# Altium schematic sheet Mcu.SchDoc
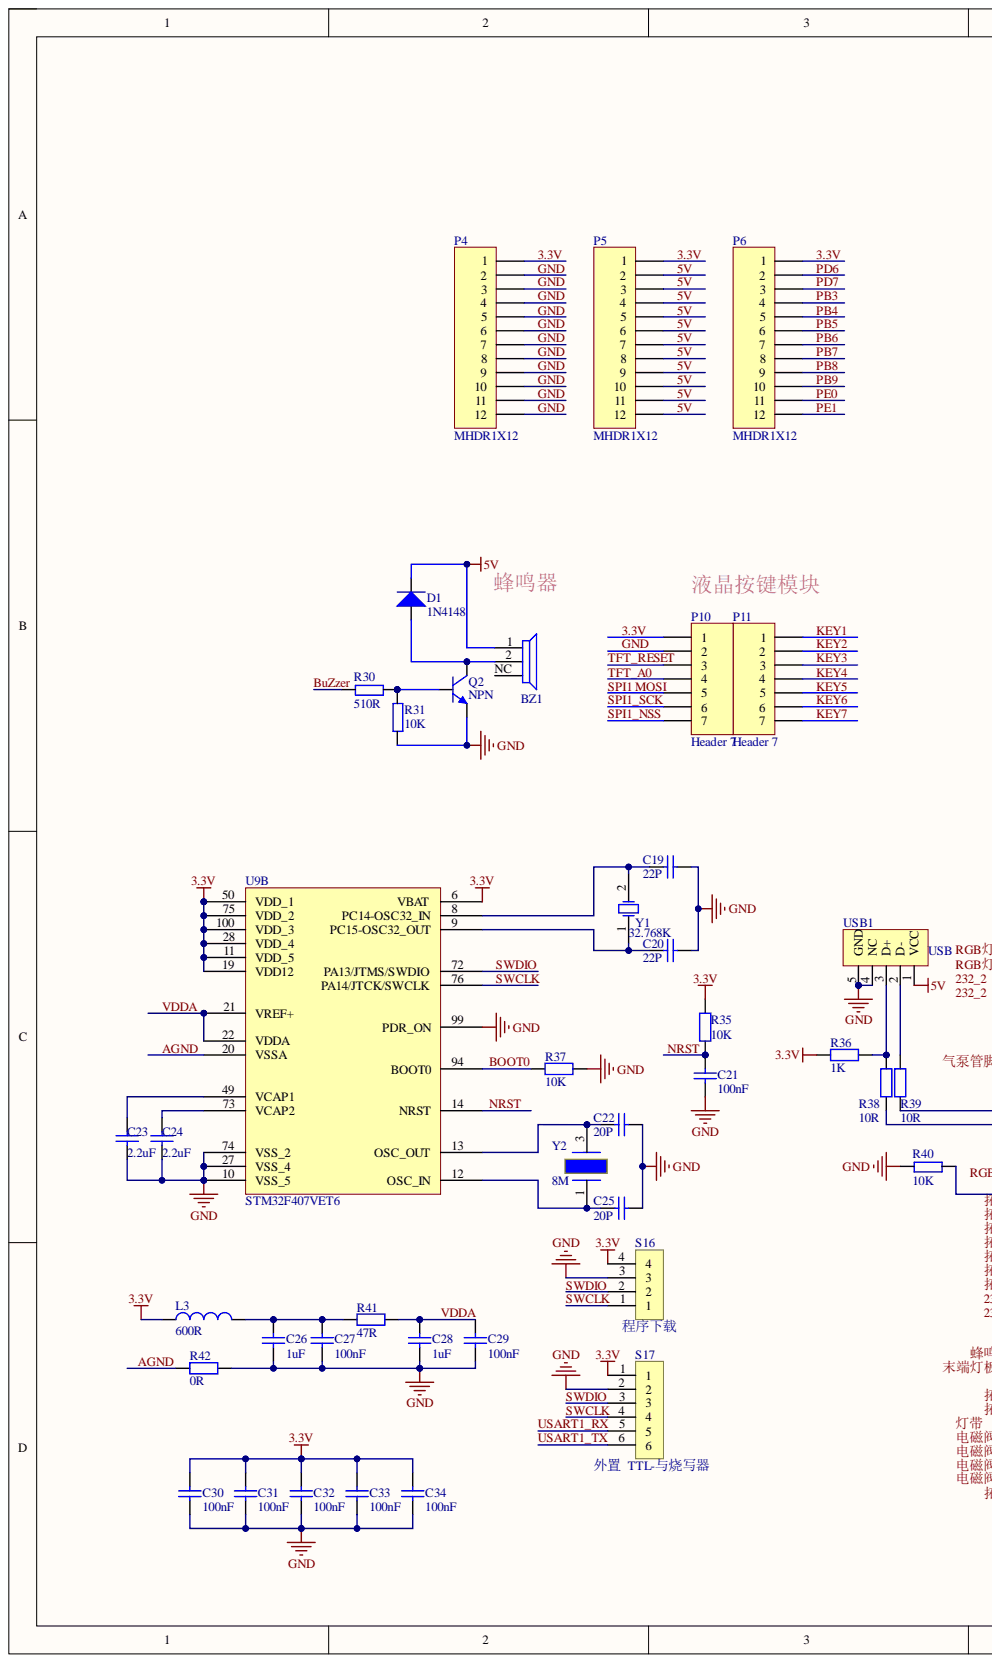
[diagram p0: Mcu.SchDoc, left]
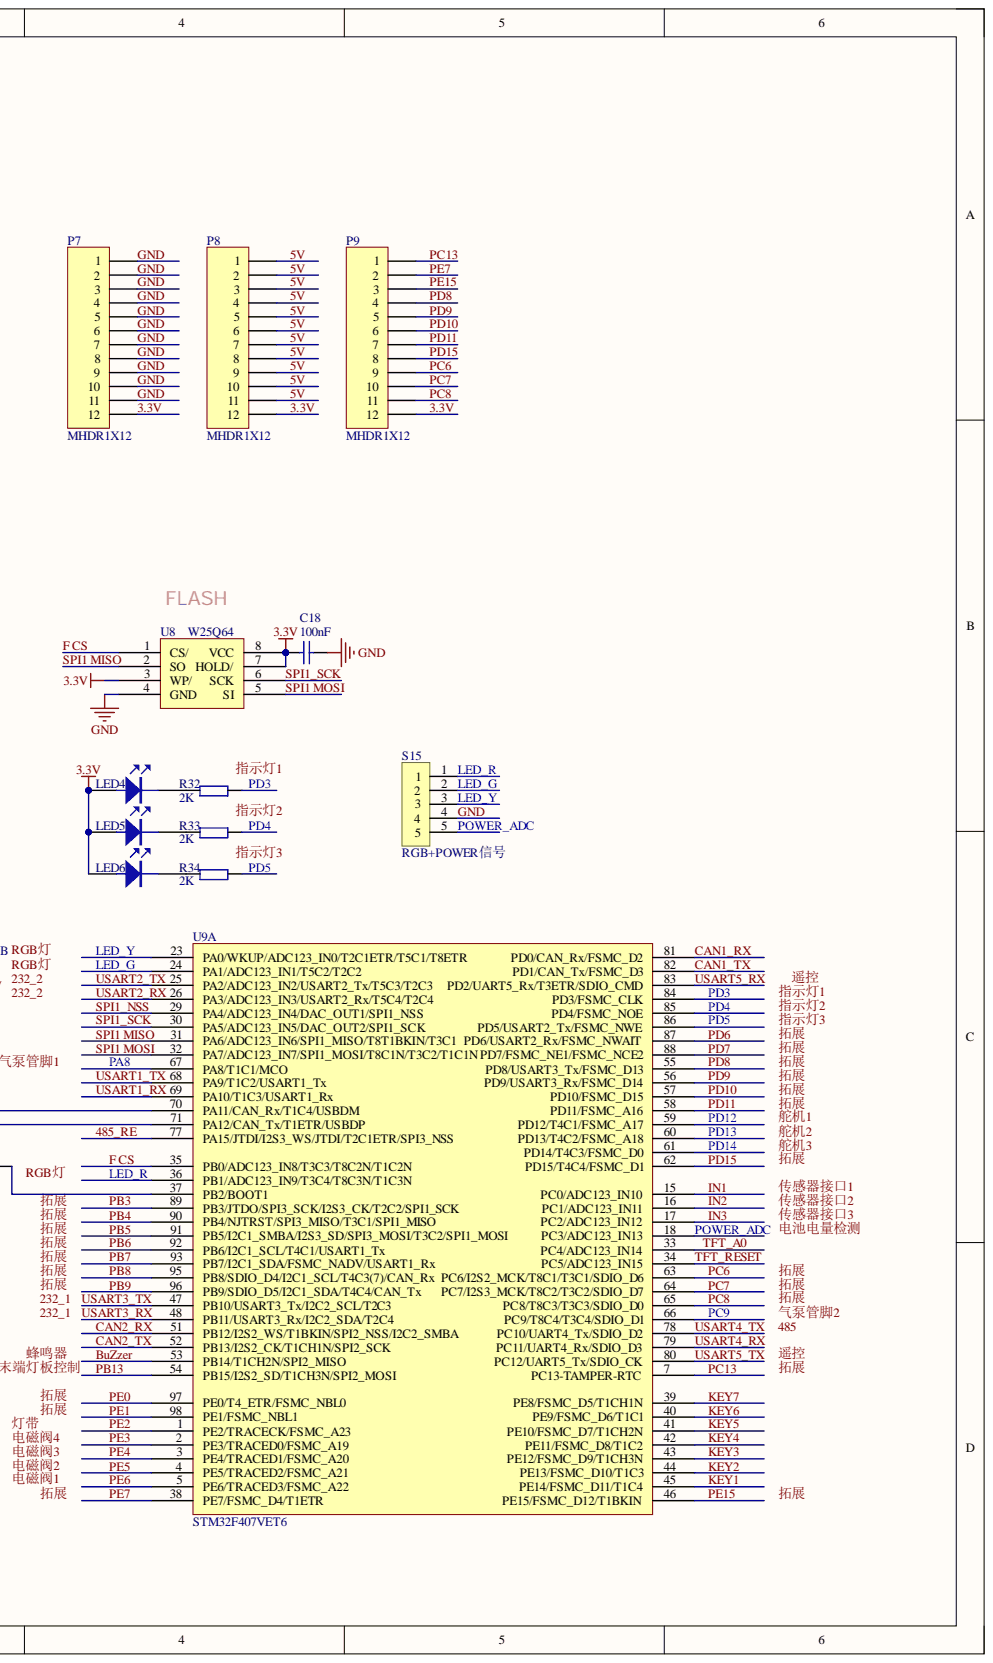
[diagram p1: Mcu.SchDoc, right]

## Parts
BZ1  蜂鸣器  [5020贴片蜂鸣器]
C18  100nF  [0402_C]
C19  22P  [0402_C]
C20  22P  [0402_C]
C21  100nF  [0402_C]
C22  20P  [0402_C]
C23  2.2uF  [0402_C]
C24  2.2uF  [0402_C]
C25  20P  [0402_C]
C26  1uF  [0402_C]
C27  100nF  [0402_C]
C28  1uF  [0402_C]
C29  100nF  [0402_C]
C30  100nF  [0402_C]
C31  100nF  [0402_C]
C32  100nF  [0402_C]
C33  100nF  [0402_C]
C34  100nF  [0402_C]
D1  1N4148  [SOD-123]
L3  600R  [0603_l]
LED4  LED  [LED 0603R]
LED5  LED  [LED 0603G]
LED6  LED  [LED 0603B]
P4  MHDR1X12  [HDR2.54-LI-12P]
P5  MHDR1X12  [HDR2.54-LI-12P]
P6  MHDR1X12  [HDR2.54-LI-12P]
P7  MHDR1X12  [HDR2.54-LI-12P]
P8  MHDR1X12  [HDR2.54-LI-12P]
P9  MHDR1X12  [HDR2.54-LI-12P]
P10  Header 7  [HDR2.54-LI-7P]
P11  Header 7  [HDR2.54-LI-7P]
Q2  NPN  [Q-NPN-SOT23]
R30  510R  [0402_R]
R31  10K  [0402_R]
R32  2K  [0402_R]
R33  2K  [0402_R]
R34  2K  [0402_R]
R35  10K  [0402_R]
R36  1K  [0402_R]
R37  10K  [0402_R]
R38  10R  [0402_R]
R39  10R  [0402_R]
R40  10K  [0402_R]
R41  47R  [0402_R]
R42  0R  [0402_R]
S15  RGB+POWER信号  [卧式PH2.0mm-5P]
S16  程序下载  [HDR2.54-LI-4P]
S17  外置  TTL-与烧写器  [卧式PH2.0mm-6P]
U8  W25Q64  [SO8W_L]
U9  STM32F407VET6  [LQFP100]  (parts A,B)
USB1  USB  [USB_A1]
Y1  32.768K  [XTAL32]
Y2  8M  [OSC 3225-4P]

## Nets
3.3V: C18.2 C30.1 C31.1 C32.1 C33.1 C34.1 L3.1 LED4.1 LED5.1 LED6.1 P4.1 P5.1 P6.1 P7.12 P8.12 P9.12 P10.1 R35.2 R36.2 S16.4 S17.1 U8.3(WP/) U8.7(HOLD/) U8.8(VCC) U9.6(VBAT) U9.11(VDD_5) U9.19(VDD12) U9.28(VDD_4) U9.50(VDD_1) U9.75(VDD_2) U9.100(VDD_3)
5V: BZ1.1 D1.2 P5.2 P5.3 P5.4 P5.5 P5.6 P5.7 P5.8 P5.9 P5.10 P5.11 P5.12 P8.1 P8.2 P8.3 P8.4 P8.5 P8.6 P8.7 P8.8 P8.9 P8.10 P8.11 USB1.1(VCC)
485_RE: U9.77(PA15/JTDI/I2S3_WS/JTDI/T2C1ETR/SPI3_NSS)
AGND: R42.1 U9.20(VSSA)
BOOT0: R37.2 U9.94(BOOT0)
BuZzer: R30.1 U9.53(PB14/T1CH2N/SPI2_MISO)
CAN1_RX: U9.81(PD0/CAN_Rx/FSMC_D2)
CAN1_TX: U9.82(PD1/CAN_Tx/FSMC_D3)
CAN2_RX: U9.51(PB12/I2S2_WS/T1BKIN/SPI2_NSS/I2C2_SMBA)
CAN2_TX: U9.52(PB13/I2S2_CK/T1CH1N/SPI2_SCK)
F CS: U8.1(CS/) U9.35(PB0/ADC123_IN8/T3C3/T8C2N/T1C2N)
GND: C18.1 C19.2 C20.2 C21.1 C22.2 C23.1 C24.1 C25.2 C26.1 C27.2 C28.2 C29.2 C30.2 C31.2 C32.2 C33.2 C34.2 P4.2 P4.3 P4.4 P4.5 P4.6 P4.7 P4.8 P4.9 P4.10 P4.11 P4.12 P7.1 P7.2 P7.3 P7.4 P7.5 P7.6 P7.7 P7.8 P7.9 P7.10 P7.11 P10.2 Q2.E R31.1 R37.1 R40.1 R42.2 S15.4 S16.3 S17.2 U8.4(GND) U9.10(VSS_5) U9.27(VSS_4) U9.74(VSS_2) U9.99(PDR_ON) USB1.4(NC) USB1.5(GND)
IN1: U9.15(PC0/ADC123_IN10)
IN2: U9.16(PC1/ADC123_IN11)
IN3: U9.17(PC2/ADC123_IN12)
KEY1: P11.1 U9.45(PE14/FSMC_D11/T1C4)
KEY2: P11.2 U9.44(PE13/FSMC_D10/T1C3)
KEY3: P11.3 U9.43(PE12/FSMC_D9/T1CH3N)
KEY4: P11.4 U9.42(PE11/FSMC_D8/T1C2)
KEY5: P11.5 U9.41(PE10/FSMC_D7/T1CH2N)
KEY6: P11.6 U9.40(PE9/FSMC_D6/T1C1)
KEY7: P11.7 U9.39(PE8/FSMC_D5/T1CH1N)
LED_G: S15.2 U9.24(PA1/ADC123_IN1/T5C2/T2C2)
LED_R: S15.1 U9.36(PB1/ADC123_IN9/T3C4/T8C3N/T1C3N)
LED_Y: S15.3 U9.23(PA0/WKUP/ADC123_IN0/T2C1ETR/T5C1/T8ETR)
NRST: C21.2 R35.1 U9.14(NRST)
PA8: U9.67(PA8/T1C1/MCO)
PB3: P6.4 U9.89(PB3/JTDO/SPI3_SCK/I2S3_CK/T2C2/SPI1_SCK)
PB4: P6.5 U9.90(PB4/NJTRST/SPI3_MISO/T3C1/SPI1_MISO)
PB5: P6.6 U9.91(PB5/I2C1_SMBA/I2S3_SD/SPI3_MOSI/T3C2/SPI1_MOSI)
PB6: P6.7 U9.92(PB6/I2C1_SCL/T4C1/USART1_Tx)
PB7: P6.8 U9.93(PB7/I2C1_SDA/FSMC_NADV/USART1_Rx)
PB8: P6.9 U9.95(PB8/SDIO_D4/I2C1_SCL/T4C3(7)/CAN_Rx)
PB9: P6.10 U9.96(PB9/SDIO_D5/I2C1_SDA/T4C4/CAN_Tx)
PB13: U9.54(PB15/I2S2_SD/T1CH3N/SPI2_MOSI)
PC6: P9.9 U9.63(PC6/I2S2_MCK/T8C1/T3C1/SDIO_D6)
PC7: P9.10 U9.64(PC7/I2S3_MCK/T8C2/T3C2/SDIO_D7)
PC8: P9.11 U9.65(PC8/T8C3/T3C3/SDIO_D0)
PC9: U9.66(PC9/T8C4/T3C4/SDIO_D1)
PC13: P9.1 U9.7(PC13-TAMPER-RTC)
PD3: R32.2 U9.84(PD3/FSMC_CLK)
PD4: R33.2 U9.85(PD4/FSMC_NOE)
PD5: R34.2 U9.86(PD5/USART2_Tx/FSMC_NWE)
PD6: P6.2 U9.87(PD6/USART2_Rx/FSMC_NWAIT)
PD7: P6.3 U9.88(PD7/FSMC_NE1/FSMC_NCE2)
PD8: P9.4 U9.55(PD8/USART3_Tx/FSMC_D13)
PD9: P9.5 U9.56(PD9/USART3_Rx/FSMC_D14)
PD10: P9.6 U9.57(PD10/FSMC_D15)
PD11: P9.7 U9.58(PD11/FSMC_A16)
PD12: U9.59(PD12/T4C1/FSMC_A17)
PD13: U9.60(PD13/T4C2/FSMC_A18)
PD14: U9.61(PD14/T4C3/FSMC_D0)
PD15: P9.8 U9.62(PD15/T4C4/FSMC_D1)
PE0: P6.11 U9.97(PE0/T4_ETR/FSMC_NBL0)
PE1: P6.12 U9.98(PE1/FSMC_NBL1)
PE2: U9.1(PE2/TRACECK/FSMC_A23)
PE3: U9.2(PE3/TRACED0/FSMC_A19)
PE4: U9.3(PE4/TRACED1/FSMC_A20)
PE5: U9.4(PE5/TRACED2/FSMC_A21)
PE6: U9.5(PE6/TRACED3/FSMC_A22)
PE7: P9.2 U9.38(PE7/FSMC_D4/T1ETR)
PE15: P9.3 U9.46(PE15/FSMC_D12/T1BKIN)
POWER_ADC: S15.5 U9.18(PC3/ADC123_IN13)
SPI1 MISO: U8.2(SO) U9.31(PA6/ADC123_IN6/SPI1_MISO/T8T1BKIN/T3C1)
SPI1 MOSI: P10.5 U8.5(SI) U9.32(PA7/ADC123_IN7/SPI1_MOSI/T8C1N/T3C2/T1C1N)
SPI1_NSS: P10.7 U9.29(PA4/ADC123_IN4/DAC_OUT1/SPI1_NSS)
SPI1_SCK: P10.6 U8.6(SCK) U9.30(PA5/ADC123_IN5/DAC_OUT2/SPI1_SCK)
SWCLK: S16.1 S17.4 U9.76(PA14/JTCK/SWCLK)
SWDIO: S16.2 S17.3 U9.72(PA13/JTMS/SWDIO)
TFT_A0: P10.4 U9.33(PC4/ADC123_IN14)
TFT_RESET: P10.3 U9.34(PC5/ADC123_IN15)
USART1_RX: S17.5 U9.69(PA10/T1C3/USART1_Rx)
USART1_TX: S17.6 U9.68(PA9/T1C2/USART1_Tx)
USART2_RX: U9.26(PA3/ADC123_IN3/USART2_Rx/T5C4/T2C4)
USART2_TX: U9.25(PA2/ADC123_IN2/USART2_Tx/T5C3/T2C3)
USART3_RX: U9.48(PB11/USART3_Rx/I2C2_SDA/T2C4)
USART3_TX: U9.47(PB10/USART3_Tx/I2C2_SCL/T2C3)
USART4_RX: U9.79(PC11/UART4_Rx/SDIO_D3)
USART4_TX: U9.78(PC10/UART4_Tx/SDIO_D2)
USART5_RX: U9.83(PD2/UART5_Rx/T3ETR/SDIO_CMD)
USART5_TX: U9.80(PC12/UART5_Tx/SDIO_CK)
VDDA: C28.1 C29.1 R41.2 U9.21(VREF+) U9.22(VDDA)
NetBZ1_2: BZ1.2 D1.1 Q2.C
NetC19_1: C19.1 U9.8(PC14-OSC32_IN) Y1.2
NetC20_1: C20.1 U9.9(PC15-OSC32_OUT) Y1.1
NetC22_1: C22.1 U9.13(OSC_OUT) Y2.3
NetC23_2: C23.2 U9.49(VCAP1)
NetC24_2: C24.2 U9.73(VCAP2)
NetC25_1: C25.1 U9.12(OSC_IN) Y2.1
NetC26_2: C26.2 C27.1 L3.2 R41.1
NetLED4_2: LED4.2 R32.1
NetLED5_2: LED5.2 R33.1
NetLED6_2: LED6.2 R34.1
NetQ2_B: Q2.B R30.2 R31.2
NetR36_1: R36.1 R38.2 USB1.3(D+)
NetR38_1: R38.1 U9.71(PA12/CAN_Tx/T1ETR/USBDP)
NetR39_1: R39.1 U9.70(PA11/CAN_Rx/T1C4/USBDM)
NetR39_2: R39.2 USB1.2(D-)
NetR40_2: R40.2 U9.37(PB2/BOOT1)
232_1:
232_2:
485:
RGB灯:
传感器接口1:
传感器接口2:
传感器接口3:
拓展:
指示灯1:
指示灯2:
指示灯3:
末端灯板控制:
气泵管脚1:
气泵管脚2:
灯带:
电池电量检测:
电磁阀1:
电磁阀2:
电磁阀3:
电磁阀4:
舵机1:
舵机2:
舵机3:
蜂鸣器:
遥控:

Not connected: BZ1 NC

## Library data of the parts
BZ1 = 蜂鸣器: Loudspeaker; Component Kind: Standard; LatestRevisionDate: 17-Jul-2002; LatestRevisionNote: Re-released for DXP Platform.; PackageReference: PIN2; Published: 24-Mar-1999; Library Name: LXD.SchLib; Publisher: Altium Limited
C18 C21 C27 C29-C34 = Cap: 无极性电容; Component Kind: Standard; LatestRevisionDate: 17-Jul-2002; LatestRevisionNote: Re-released for DXP Platform.; PackageReference: RAD-0.3; Published: 8-Jun-2000; Value: 100nF; Library Name: Fszhang.IntLib; Publisher: Altium Limited
C19 C20 C22-C26 C28 = Cap: 无极性电容; Value: 100nF; Component Kind: Standard; LatestRevisionDate: 17-Jul-2002; LatestRevisionNote: Re-released for DXP Platform.; PackageReference: RAD-0.3; Published: 8-Jun-2000; Library Name: Fszhang.IntLib; Publisher: Altium Limited
D1 = Diode 1N4148: High Conductance Fast Diode; Code_JEDEC: DO-204-AH; Component Kind: Standard; ComponentLink1Description: Manufacturer Link; ComponentLink1URL: http://www.fairchildsemi.com/; ComponentLink2Description: Datasheet; ComponentLink2URL: http://www.fairchildsemi.com/ds/1N%2F1N4148.pdf; DatasheetVersion: 1997; LatestRevisionDate: 17-Jul-2002; LatestRevisionNote: Re-released for DXP Platform.; Library Name: Miscellaneous Devices.IntLib; PackageDescription: Diode, Axial; Body 3.8 x 1.9 mm (LxDia. max), Lead Dia. 0.53 mm (max); PackageReference: DO-35; Published: 8-Jun-2000; Signal Integrity: 1N4148; Simulation: 1N4148; Publisher: Altium Limited
L3 = Inductor: Code_IEC: 1005; Value: 10mH; Code_JEITA: 0402; Component Kind: Standard; Description: Inductor; LatestRevisionDate: 29-May-2009; LatestRevisionNote: IPC-7351 Footprint Added.; Library Name: Miscellaneous Devices.IntLib; PackageDescription: Chip Inductor; PackageReference: 0402-A; Published: 8-Jun-2000; Signal Integrity: Inductor; Simulation: INDUCTOR; Publisher: Altium Limited
P4-P9 = MHDR1X12: Header, 12-Pin; Component Kind: Standard; LatestRevisionDate: 17-Jul-2002; LatestRevisionNote: Re-released for DXP Platform.; Library Name: Miscellaneous Connectors.IntLib; Signal Integrity: Connector; Publisher: Altium Limited
P10 P11 = Header 7: Header, 7-Pin; Component Kind: Standard; LatestRevisionDate: 17-Jul-2002; LatestRevisionNote: Re-released for DXP Platform.; Library Name: Miscellaneous Connectors.IntLib; Signal Integrity: Connector; Publisher: Altium Limited
Q2 = C4116: Component Kind: Standard; Library Name: synthesize.SchLib
R30-R34 R40 = R: Component Kind: Standard
  R30-R34: Library Name: WARSHIP.SCHLIB
  R40: Library Name: RM9250.2.SCHLIB
R35 R37 R41 R42 = Res1: 贴片电阻; Value: 1K; Component Kind: Standard; LatestRevisionDate: 17-Jul-2002; LatestRevisionNote: Re-released for DXP Platform.; PackageReference: AXIAL-0.4; Published: 8-Jun-2000; Library Name: Fszhang.IntLib; Publisher: Altium Limited
R36 R38 R39 = Res2: Resistor; Value: 10; Component Kind: Standard; LatestRevisionDate: 17-Jul-2002; LatestRevisionNote: Re-released for DXP Platform.; Library Name: Miscellaneous Devices.IntLib; PackageDescription: Axial Device, Thru-Hole; 2 Leads; 0.4 in Pin Spacing; PackageReference: AXIAL-0.4; Published: 8-Jun-2000; Signal Integrity: Res; Simulation: RESISTOR; Publisher: Altium Limited
S15 = S-5: Component Kind: Standard; Library Name: ZBC123Schlib1.SchLib
S16 = S-4: Component Kind: Standard; Library Name: ZBC123Schlib1.SchLib
S17 = S-6: Component Kind: Standard; Library Name: ZBC123Schlib1.SchLib
U8 = W25X10: Component Kind: Standard
U9 = STM32F407V: STM32单片机100引脚; Component Kind: Standard; Library Name: LXD.SchLib
USB1 = USB5: Component Kind: Standard; Library Name: WARSHIP.SCHLIB
Y1 = XTAL_1: Crystal Oscillator; Component Kind: Standard; LatestRevisionDate: 17-Jul-2002; LatestRevisionNote: Re-released for DXP Platform.; Library Name: STM32F407ZGT6.SCHLIB; Published: 8-Jun-2000; Simulation: XTAL; Publisher: Altium Limited
Y2 = JZ: Component Kind: Standard; Library Name: ZBC124Schlib1.SchLib
LED4-LED6 = LED: Component Kind: Standard; Library Name: ZBC124Schlib1.SchLib
